# Altium schematic sheet POWER_MCU.SchDoc
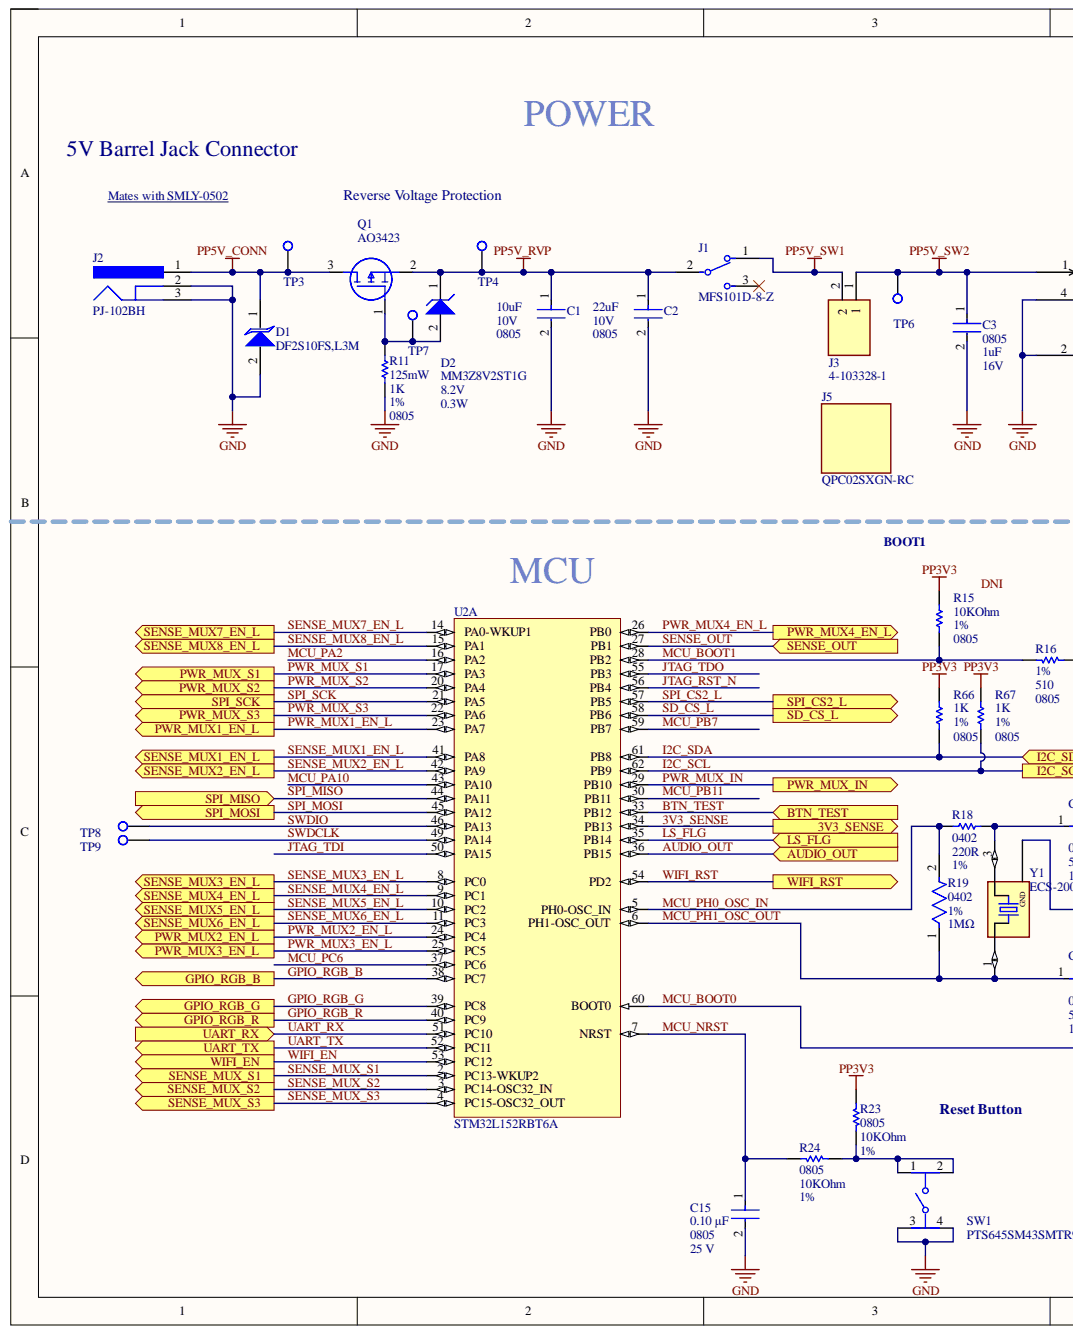
[diagram p0: POWER_MCU.SchDoc, left]
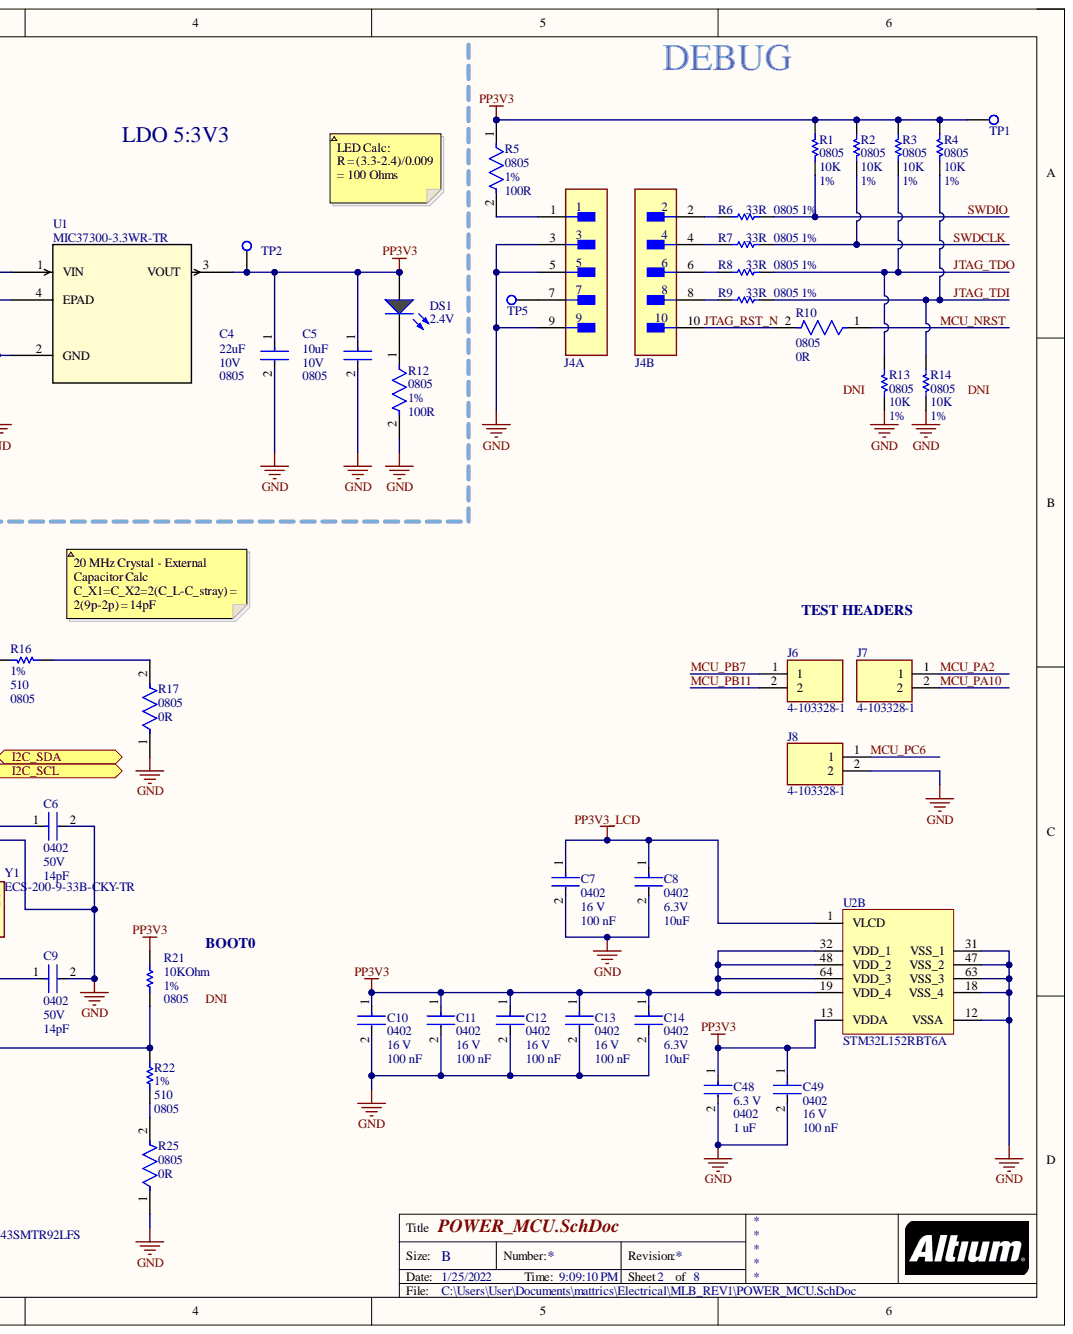
[diagram p1: POWER_MCU.SchDoc, right]

## Parts
C1  Capacitance: 10uF  Case/Package: 0805  Voltage Rating (DC): 10V  [FP-GRM21B-0_15-IPC_A]  (hidden comment: GRM21BR71A106KA73K)
C2  Capacitance: 22uF  Case Code (Imperial): 0805  Voltage Rating: 10V  [FP-GRM21B-0_15-IPC_A]  (hidden comment: GRM21BR61A226ME51L)
C3  Capacitance: 1uF  Case Code (Imperial): 0805  Voltage: 16V  [FP-CL21-IPC_A]  (hidden comment: CL21B105KOFNNNE)
C4  Capacitance: 22uF  Case Code (Imperial): 0805  Voltage Rating: 10V  [FP-GRM21B-0_15-IPC_A]  (hidden comment: GRM21BR61A226ME51L)
C5  Capacitance: 10uF  Case/Package: 0805  Voltage Rating (DC): 10V  [FP-GRM21B-0_15-IPC_A]  (hidden comment: GRM21BR71A106KA73K)
C6  Capacitance: 14pF  Package Reference: 0402  Voltage Rating (DC): 50V  [FP-CC0402-IPC_B]  (hidden comment: GJM1555C1H140GB01D)
C7  value 100 nF  Capacitance: 100 nF  Package: 0402  Voltage Rating: 16 V  [FP-CC0402-IPC_B]  (hidden comment: CC0402KRX7R7BB104)
C8  Capacitance: 10uF  Package: 0402  Voltage: 6.3V  [FP-CC0402-IPC_B]  (hidden comment: GRM155R60J106ME05D)
C9  Capacitance: 14pF  Package Reference: 0402  Voltage Rating (DC): 50V  [FP-CC0402-IPC_B]  (hidden comment: GJM1555C1H140GB01D)
C10  value 100 nF  Package: 0402  Voltage Rating: 16 V  [FP-CC0402-IPC_B]  (hidden comment: CC0402KRX7R7BB104)
C11  value 100 nF  Capacitance: 100 nF  Package: 0402  Voltage Rating: 16 V  [FP-CC0402-IPC_B]  (hidden comment: CC0402KRX7R7BB104)
C12  value 100 nF  Capacitance: 100 nF  Package: 0402  Voltage Rating: 16 V  [FP-CC0402-IPC_B]  (hidden comment: CC0402KRX7R7BB104)
C13  value 100 nF  Capacitance: 100 nF  Package: 0402  Voltage Rating: 16 V  [FP-CC0402-IPC_B]  (hidden comment: CC0402KRX7R7BB104)
C14  Capacitance: 10uF  Package: 0402  Voltage: 6.3V  [FP-CC0402-IPC_B]  (hidden comment: GRM155R60J106ME05D)
C15  value 0.10 μF  Capacitance: 0.10 μF  Package: 0805  Voltage Rating: 25 V  [FP-C0805C-DN-MFG]  (hidden comment: C0805C104M3RACTU)
C48  value 1 uF  Capacitance: 1 uF  Package: 0402  Voltage Rating: 6.3 V  [FP-CC0402-IPC_B]  (hidden comment: CC0402KRX5R5BB105)
C49  value 100 nF  Capacitance: 100 nF  Package: 0402  Voltage Rating: 16 V  [FP-CC0402-IPC_B]  (hidden comment: CC0402KRX7R7BB104)
D1  DF2S10FS,L3M  [DIOM106X45N]
D2  MM3Z8V2ST1G  [ONSC-SOD-323-2-477-02_V]
DS1  Forward Voltage: 2.4V  [DIAL-0805-2_V]  (hidden comment: 598-8170-107F)
J1  MFS101D-8-Z  [CONN03_MFS_11P8X5P8_NDC]
J2  PJ-102BH  [CUI-PJ-102BH_V]
J3  4-103328-1  [TE_4-103328-1]
J4  3220-10-0300-00  [CNC_Tech_0_MFG]  (value not drawn)  (parts A,B)
J5  QPC02SXGN-RC  [FP-QPC02SXGN-RC-MFG]
J6  4-103328-1  [TE_4-103328-1]
J7  4-103328-1  [TE_4-103328-1]
J8  4-103328-1  [TE_4-103328-1]
Q1  AO3423  [SOT95P280X125-3N]
R1  value 10K  PackageReference: 0805  Tolerance: 1%  [RESC2013X60X35NL10T20]  (hidden comment: RC0805FR-0710KL)
R2  value 10K  PackageReference: 0805  Tolerance: 1%  [RESC2013X60X35NL10T20]  (hidden comment: RC0805FR-0710KL)
R3  value 10K  PackageReference: 0805  Tolerance: 1%  [RESC2013X60X35NL10T20]  (hidden comment: RC0805FR-0710KL)
R4  value 10K  PackageReference: 0805  Tolerance: 1%  [RESC2013X60X35NL10T20]  (hidden comment: RC0805FR-0710KL)
R5  Case Code (Imperial): 0805  Resistance: 100R  Tolerance: 1%  [FP-RMCF0805-IPC_A]  (hidden comment: RMCF0805FT100R)
R6  Package Reference: 0805  Resistance: 33R  Tolerance: 1%  [RESC2013X60X35NL10T20]  (hidden comment: RC0805FR-0733RL)
R7  Package Reference: 0805  Resistance: 33R  Tolerance: 1%  [RESC2013X60X35NL10T20]  (hidden comment: RC0805FR-0733RL)
R8  Package Reference: 0805  Resistance: 33R  Tolerance: 1%  [RESC2013X60X35NL10T20]  (hidden comment: RC0805FR-0733RL)
R9  Package Reference: 0805  Resistance: 33R  [RESC2013X60X35NL10T20]  (hidden comment: RC0805FR-0733RL)
R10  Case Code (Imperial): 0805  Resistance: 0R  [FP-RMCF0805-MFG]  (hidden comment: RMCF0805ZT0R00)
R11  Case Code (Imperial): 0805  Max Power Dissipation: 125mW  Resistance: 1K  Tolerance: 1%  [RESC2013X60X35ML10T20]  (hidden comment: RC0805FR-071KL)
R12  Case Code (Imperial): 0805  Resistance: 100R  Tolerance: 1%  [FP-RMCF0805-IPC_A]  (hidden comment: RMCF0805FT100R)
R13  value 10K  PackageReference: 0805  Tolerance: 1%  [RESC2013X60X35NL10T20]  (hidden comment: RC0805FR-0710KL)
R14  value 10K  PackageReference: 0805  Tolerance: 1%  [RESC2013X60X35NL10T20]  (hidden comment: RC0805FR-0710KL)
R15  value 10KOhm  PackageReference: 0805  Tolerance: 1%  [RESC2013X60X35NL10T20]  (hidden comment: RC0805FR-0710KL)
R16  Package Reference: 0805  Tolerance: 1%  [RESC2013X70X40NL20T20]  (hidden comment: ERJ-6ENF5100V)
R17  Case Code (Imperial): 0805  Resistance: 0R  [FP-RMCF0805-MFG]  (hidden comment: RMCF0805ZT0R00)
R18  Package Reference: 0402  [FP-CC0402-IPC_B]  (hidden comment: RC0402FR-07220RL)
R19  value 1MΩ  Package Reference: 0402  Resistance: 1MΩ  Tolerance: 1%  [FP-CC0402-IPC_B]  (hidden comment: CRGCQ0402F1M0)
R21  value 10KOhm  PackageReference: 0805  Tolerance: 1%  [RESC2013X60X35NL10T20]  (hidden comment: RC0805FR-0710KL)
R22  Package Reference: 0805  Tolerance: 1%  [RESC2013X70X40NL20T20]  (hidden comment: ERJ-6ENF5100V)
R23  value 10KOhm  PackageReference: 0805  Tolerance: 1%  [RESC2013X60X35NL10T20]  (hidden comment: RC0805FR-0710KL)
R24  value 10KOhm  Package Reference: 0805  Tolerance: 1%  [RESC2013X50X30NL20T20]  (hidden comment: CRCW080515K0FKTA)
R25  Case Code (Imperial): 0805  Resistance: 0R  [FP-RMCF0805-MFG]  (hidden comment: RMCF0805ZT0R00)
R66  Resistance: 1K  Tolerance: 1%  [RESC2013X60X35ML10T20]  (hidden comment: RC0805FR-071KL)
R67  Case Code (Imperial): 0805  Resistance: 1K  Tolerance: 1%  [RESC2013X60X35ML10T20]  (hidden comment: RC0805FR-071KL)
SW1  PTS645SM43SMTR92LFS  [FP-PTS645SM43SMTR92LFS-MFG]
TP1  RCU-0C  [TP+195X110]  (value not drawn)
TP2  RCU-0C  [TP+195X110]  (value not drawn)
TP3  RCU-0C  [TP+195X110]  (value not drawn)
TP4  RCU-0C  [TP+195X110]  (value not drawn)
TP5  RCU-0C  [TP+195X110]  (value not drawn)
TP6  RCU-0C  [TP+195X110]  (value not drawn)
TP7  RCU-0C  [TP+195X110]  (value not drawn)
TP8  RCU-0C  [TP+195X110]  (value not drawn)
TP9  RCU-0C  [TP+195X110]  (value not drawn)
U1  MIC37300-3.3WR-TR  [S-PAK-3_R_MCH]
U2  STM32L152RBT6A  [STM-LQFP64_L]  (parts A,B)
Y1  ECS-200-9-33B-CKY-TR  [XTAL_ECS-260-9-33B-CKY-TR]

## Ports
3V3_SENSE, AUDIO_OUT, BTN_TEST, GPIO_RGB_B, GPIO_RGB_G, GPIO_RGB_R, I2C_SCL, I2C_SDA, LS_FLG, PWR_MUX1_EN_L, PWR_MUX2_EN_L, PWR_MUX3_EN_L, PWR_MUX4_EN_L, PWR_MUX_IN, PWR_MUX_S1, PWR_MUX_S2, PWR_MUX_S3, SD_CS_L, SENSE_MUX1_EN_L, SENSE_MUX2_EN_L, SENSE_MUX3_EN_L, SENSE_MUX4_EN_L, SENSE_MUX5_EN_L, SENSE_MUX6_EN_L, SENSE_MUX7_EN_L, SENSE_MUX8_EN_L, SENSE_MUX_S1, SENSE_MUX_S2, SENSE_MUX_S3, SENSE_OUT, SPI_CS2_L, SPI_MISO, SPI_MOSI, SPI_SCK, UART_RX, UART_TX, WIFI_EN, WIFI_RST

## Nets
GND: C1.2 C2.2 C3.2 C4.2 C5.2 C6.2 C7.2 C8.2 C9.2 C10.2 C11.2 C12.2 C13.2 C14.2 C15.2 C48.2 C49.2 D1.2 J2.2 J2.3 J4.3 J4.5 J4.9 J8.2 R11.1 R12.2 R13.1 R14.1 R17.1 R25.1 SW1.3 SW1.4 U1.2(GND) U1.4(EPAD) U2.12(VSSA) U2.18(VSS_4) U2.31(VSS_1) U2.47(VSS_2) U2.63(VSS_3) Y1.4
PP3V3: C4.1 C5.1 C10.1 C11.1 C12.1 C13.1 C14.1 C48.1 C49.1 DS1.1 R1.2 R2.2 R3.2 R4.2 R5.1 R15.2 R21.2 R23.2 R66.1 R67.1 TP1.1 TP2.1 U1.3(VOUT) U2.13(VDDA) U2.19(VDD_4) U2.32(VDD_1) U2.48(VDD_2) U2.64(VDD_3)
PP3V3_LCD: C7.1 C8.1 U2.1(VLCD)
PP5V_CONN: D1.1 J2.1 Q1.3 TP3.1
PP5V_RVP: C1.1 C2.1 D2.1 J1.2 Q1.2 TP4.1
PP5V_SW1: J1.1 J3.2
PP5V_SW2: C3.1 J3.1 TP6.1 U1.1(VIN)
3V3_SENSE: U2.34(PB13) ; port 3V3_SENSE
AUDIO_OUT: U2.36(PB15) ; port AUDIO_OUT
BTN_TEST: U2.33(PB12) ; port BTN_TEST
GPIO_RGB_B: U2.38(PC7) ; port GPIO_RGB_B
GPIO_RGB_G: U2.39(PC8) ; port GPIO_RGB_G
GPIO_RGB_R: U2.40(PC9) ; port GPIO_RGB_R
I2C_SCL: R67.2 U2.62(PB9) ; port I2C_SCL
I2C_SDA: R66.2 U2.61(PB8) ; port I2C_SDA
JTAG_RST_N: J4.10 R10.2 U2.56(PB4)
JTAG_TDI: R4.1 R9.2 R14.2 U2.50(PA15)
JTAG_TDO: R3.1 R8.2 R13.2 U2.55(PB3)
LS_FLG: U2.35(PB14) ; port LS_FLG
MCU_BOOT0: R21.1 R22.2 U2.60(BOOT0)
MCU_BOOT1: R15.1 R16.2 U2.28(PB2)
MCU_NRST: C15.1 R10.1 R24.2 U2.7(NRST)
MCU_PA2: J7.1 U2.16(PA2)
MCU_PA10: J7.2 U2.43(PA10)
MCU_PB7: J6.1 U2.59(PB7)
MCU_PB11: J6.2 U2.30(PB11)
MCU_PC6: J8.1 U2.37(PC6)
MCU_PH0_OSC_IN: R18.1 R19.2 U2.5(PH0-OSC_IN)
MCU_PH1_OSC_OUT: C9.1 R19.1 U2.6(PH1-OSC_OUT) Y1.1
PWR_MUX1_EN_L: U2.23(PA7) ; port PWR_MUX1_EN_L
PWR_MUX2_EN_L: U2.24(PC4) ; port PWR_MUX2_EN_L
PWR_MUX3_EN_L: U2.25(PC5) ; port PWR_MUX3_EN_L
PWR_MUX4_EN_L: U2.26(PB0) ; port PWR_MUX4_EN_L
PWR_MUX_IN: U2.29(PB10) ; port PWR_MUX_IN
PWR_MUX_S1: U2.17(PA3) ; port PWR_MUX_S1
PWR_MUX_S2: U2.20(PA4) ; port PWR_MUX_S2
PWR_MUX_S3: U2.22(PA6) ; port PWR_MUX_S3
SD_CS_L: U2.58(PB6) ; port SD_CS_L
SENSE_MUX1_EN_L: U2.41(PA8) ; port SENSE_MUX1_EN_L
SENSE_MUX2_EN_L: U2.42(PA9) ; port SENSE_MUX2_EN_L
SENSE_MUX3_EN_L: U2.8(PC0) ; port SENSE_MUX3_EN_L
SENSE_MUX4_EN_L: U2.9(PC1) ; port SENSE_MUX4_EN_L
SENSE_MUX5_EN_L: U2.10(PC2) ; port SENSE_MUX5_EN_L
SENSE_MUX6_EN_L: U2.11(PC3) ; port SENSE_MUX6_EN_L
SENSE_MUX7_EN_L: U2.14(PA0-WKUP1) ; port SENSE_MUX7_EN_L
SENSE_MUX8_EN_L: U2.15(PA1) ; port SENSE_MUX8_EN_L
SENSE_MUX_S1: U2.2(PC13-WKUP2) ; port SENSE_MUX_S1
SENSE_MUX_S2: U2.3(PC14-OSC32_IN) ; port SENSE_MUX_S2
SENSE_MUX_S3: U2.4(PC15-OSC32_OUT) ; port SENSE_MUX_S3
SENSE_OUT: U2.27(PB1) ; port SENSE_OUT
SPI_CS2_L: U2.57(PB5) ; port SPI_CS2_L
SPI_MISO: U2.44(PA11) ; port SPI_MISO
SPI_MOSI: U2.45(PA12) ; port SPI_MOSI
SPI_SCK: U2.21(PA5) ; port SPI_SCK
SWDCLK: R2.1 R7.2 TP9.1 U2.49(PA14)
SWDIO: R1.1 R6.2 TP8.1 U2.46(PA13)
UART_RX: U2.51(PC10) ; port UART_RX
UART_TX: U2.52(PC11) ; port UART_TX
WIFI_EN: U2.53(PC12) ; port WIFI_EN
WIFI_RST: U2.54(PD2) ; port WIFI_RST
NetC6_1: C6.1 R18.2 Y1.3
NetD2_2: D2.2 Q1.1 R11.2 TP7.1
NetDS1_2: DS1.2 R12.1
NetJ4_1: J4.1 R5.2
NetJ4_2: J4.2 R6.1
NetJ4_4: J4.4 R7.1
NetJ4_6: J4.6 R8.1
NetJ4_7: J4.7 TP5.1
NetJ4_8: J4.8 R9.1
NetR16_1: R16.1 R17.2
NetR22_1: R22.1 R25.2
NetR23_1: R23.1 R24.1 SW1.1 SW1.2

Not connected: J1 3

## Library data of the parts
C1 C5 = CMP-06035-038134-1: Chip Multilayer Ceramic Capacitors for General Purpose, 0805, 10uF, X7R, 15%, 10%, 10V; Manufacturer: Murata Electronics; Component Kind: Standard; Dielectric: X7R; Length: 2mm; Library Name: Altium Content Vault; Manufacturer Part Number: GRM21BR71A106KA73K; Supplier: Digi-Key; Supplier Part Number: 490-14381-1-ND; Thickness: 1.25mm; Tolerance: 10%; Width: 1.25mm
C2 C4 = CMP-2000-06340-2: Chip Multilayer Ceramic Capacitors for General Purpose, 0805, 22uF, X5R, 15%, 20%, 10V; Manufacturer: Murata Electronics; Case Code (Metric): 2012; Case/Package: 0805; Component Kind: Standard; Depth: 1.25mm; Dielectric: X5R; Height: 1.25mm; Lead Free: Lead Free; Length: 2mm; Library Name: Altium Content Vault; Manufacturer Part Number: GRM21BR61A226ME51L; Max Operating Temperature: 85°C; Min Operating Temperature: -55°C; Mount: Surface Mount; Package Quantity: 3000; Packaging: Tape and Reel; Radiation Hardening: No; REACH SVHC: No SVHC; Supplier: Digi-Key; Supplier Part Number: 490-10511-1-ND; Termination: SMD/SMT; Thickness: 0.055inch; Tolerance: 20%; Voltage Rating (DC): 10V; Weight: 0.000335oz; Width: 0.049inch
C3 = CMP-13271-003098-1: CL21 Series 0805 1uF 16V ±10% Tolerance X7R Multilayer Ceramic Chip Capacitor; Manufacturer: Samsung Electro-Mechanics; Case Code (Metric): 2012; Case/Package: 0805; Component Kind: Standard; Contact Plating: Tin; Depth: 1.25mm; Dielectric: X7R; Height: 1.25mm; Lead Free: Lead Free; Length: 2mm; Library Name: MLBSchLib.SchLib; Manufacturer Part Number: CL21B105KOFNNNE; Max Operating Temperature: 125°C; Min Operating Temperature: -55°C; Mount: Surface Mount; Package Quantity: 1; Packaging: Tape and Reel; Radiation Hardening: No; Supplier: Digi-Key; Supplier Part Number: 1276-1026-1-ND; Thickness: 0.053inch; Tolerance: 10%; Voltage Rating: 16V; Voltage Rating (DC): 16V; Width: 0.049inch
C6 C9 = CMP-06035-028518-1: Multi-Layer Ceramic Capacitor 14pF C0G  ±2% 0402 Paper T/R; Manufacturer: Murata Electronics; Component Kind: Standard; Dielectric: C0G; Length: 1mm; Library Name: MLBSchLib.SchLib; Manufacturer Part Number: GJM1555C1H140GB01D; Supplier: Digi-Key; Supplier Part Number: 490-14443-1-ND; Thickness: 500um; Tolerance: 2%; Width: 500um
C7 C11-C13 C49 = CMP-03422-000048-1: CAP CER 0.1UF 16V X7R 0402; Manufacturer: YAGEO; Automotive Grade: NA; Material: Ceramic; Temperature Coefficient: 30ppm/°C; Tolerance: 0.1; Automotive: No; Category: Cap; Component Kind: Standard; ComponentLink2Description: Datasheet; ComponentLink2URL: http://www.yageo.com/documents/recent/UPY-GP_NP0_16V-to-50V_16.pdf; Device Class L1: Passive Components; Device Class L2: Capacitors; Device Class L3: Ceramic Capacitors; Digikey Description: CAP CER 0.1UF 16V X7R 0402; Footprint Url: http://www.yageo.com/exep/pages/download/literatures/UPY-C_GEN_24.pdf; Height: 0.55mm; Ipc Land Pattern Name: CAPC10050X50; Lead Free: Yes; Library Name: MLBSchLib.SchLib; Manufacturer Part Number: CC0402KRX7R7BB104; Mouser Description: 0402 0.1 uF 16 V ±10 % Tolerance X7R SMT Multilayer Ceramic Capacitor; Octopart: https://octopart.com/cc0402krx7r7bb104-yageo-42244624; RoHS: TRUE; Supplier: Digi-Key; Supplier Part Number: 311-1338-1-ND; Temperature: -55°C to +125°C; Temperature Characteristic: X7R; Temperature Range High: +125°C; Temperature Range Low: -55°C
C8 C14 = CMP-06035-044652-1: Chip Multilayer Ceramic Capacitors for General Purpose, 0402, 10uF, X5R, 15%, 20%, 6.3V; Manufacturer: Murata Electronics; Component Kind: Standard; Library Name: MLBSchLib.SchLib; Manufacturer Part Number: GRM155R60J106ME05D; Supplier: Digi-Key; Supplier Part Number: 490-GRM155R60J106ME05DCT-ND
C10 = CMP-03422-000048-1: CAP CER 0.1UF 16V X7R 0402; Manufacturer: YAGEO; Automotive Grade: NA; Capacitance: 100 nF; Material: Ceramic; Temperature Coefficient: 30ppm/°C; Tolerance: 0.1; Value: 100 nF; Automotive: No; Category: Cap; Component Kind: Standard; ComponentLink2Description: Datasheet; ComponentLink2URL: http://www.yageo.com/documents/recent/UPY-GP_NP0_16V-to-50V_16.pdf; Device Class L1: Passive Components; Device Class L2: Capacitors; Device Class L3: Ceramic Capacitors; Digikey Description: CAP CER 0.1UF 16V X7R 0402; Footprint Url: http://www.yageo.com/exep/pages/download/literatures/UPY-C_GEN_24.pdf; Height: 0.55mm; Ipc Land Pattern Name: CAPC10050X50; Lead Free: Yes; Library Name: MLBSchLib.SchLib; Manufacturer Part Number: CC0402KRX7R7BB104; Mouser Description: 0402 0.1 uF 16 V ±10 % Tolerance X7R SMT Multilayer Ceramic Capacitor; Octopart: https://octopart.com/cc0402krx7r7bb104-yageo-42244624; RoHS: TRUE; Supplier: Digi-Key; Supplier Part Number: 311-1338-1-ND; Temperature: -55°C to +125°C; Temperature Characteristic: X7R; Temperature Range High: +125°C; Temperature Range Low: -55°C
C15 = CMP-2007-00282-2: CAP CER 0.1UF 25V 20% X7R 0805; Manufacturer: KEMET; Material: Ceramic; Temperature Coefficient: 30ppm/°C; Tolerance: 0.2; Automotive: No; Category: Cap; Component Kind: Standard; ComponentLink2Description: Datasheet; ComponentLink2URL: https://content.kemet.com/datasheets/KEM_C1003_C0G_SMD.pdf; Device Class L1: Passive Components; Device Class L2: Capacitors; Device Class L3: Ceramic Capacitors; Digikey Description: CAP CER 0.1UF 25V 20% X7R 0805; Height: 0.88mm; Ipc Land Pattern Name: CAPC200125X78; Lead Free: Yes; Library Name: Altium Content Vault; Manufacturer Part Number: C0805C104M3RACTU; Mouser Description: Multilayer Ceramic Capacitors MLCC - SMD/SMT 25volts 0.1uF X7R 20%; Octopart: https://octopart.com/c0805c104m3ractu-kemet-9138612; RoHS: TRUE; Supplier: Digi-Key; Supplier Part Number: 399-C0805C104M3RAC7800CT-ND; Temperature: -55°C to +125°C; Temperature Characteristic: X7R; Temperature Range High: +125°C; Temperature Range Low: -55°C
C48 = CMP-2100-03591-2: CAP CER 1UF 6.3V X5R 0402; Manufacturer: YAGEO; Automotive Grade: NA; Material: Ceramic; Temperature Coefficient: 30ppm/°C; Tolerance: 0.1; Automotive: No; Category: Cap; Component Kind: Standard; ComponentLink2Description: Datasheet; ComponentLink2URL: http://www.yageo.com/documents/recent/UPY-GP_NP0_16V-to-50V_16.pdf; Device Class L1: Passive Components; Device Class L2: Capacitors; Device Class L3: Ceramic Capacitors; Digikey Description: CAP CER 1UF 6.3V X5R 0402; Footprint Url: http://www.yageo.com/exep/pages/download/literatures/UPY-C_GEN_24.pdf; Height: 0.55mm; Ipc Land Pattern Name: CAPC10050X50; Lead Free: Yes; Library Name: MLBSchLib.SchLib; Manufacturer Part Number: CC0402KRX5R5BB105; Mouser Description: Multilayer Ceramic Capacitors MLCC - SMD/SMT 1uF 6.3V X5R 10%; Octopart: https://octopart.com/cc0402krx5r5bb105-yageo-42244618; RoHS: TRUE; Supplier: Digi-Key; Supplier Part Number: 311-1438-1-ND; Temperature: -55°C to +125°C; Temperature Characteristic: X5R; Temperature Range High: +125°C; Temperature Range Low: -55°C
D1 = DF2S10FS,L3M: TVS DIODE 8VWM FSC; Manufacturer: Toshiba Semiconductor and Storage; Manufacturer_Name: Toshiba; Arrow Part Number: DF2S10FS,L3M; Arrow Price/Stock: https://www.arrow.com/en/products/df2s10fsl3m/toshiba?region=nac; Component Kind: Standard; Datasheet Link: https://componentsearchengine.com/Datasheets/1/DF2S10FS,L3M.pdf; Height: 0.45mm; Library Name: MLBSchLib.SchLib; Manufacturer Part Number: DF2S10FS,L3M; Manufacturer_Part_Number: DF2S10FS,L3M; Mouser Part Number: 757-DF2S10FSL3M; Mouser Price/Stock: https://www.mouser.co.uk/ProductDetail/Toshiba/DF2S10FSL3M?qs=lhkzy8lC%252BSsM5fAm2E7mCQ%3D%3D; Supplier: Digi-Key; Supplier Part Number: DF2S10FSL3MCT-ND
D2 = MM3Z8V2ST1G: Zener Voltage Regulator, 300 mW, Tight Tolerance Portfolio, 2-Pin SOD-323, Pb-Free, Tape and Reel; Manufacturer: On Semiconductor; P Max (W): 0.3W; Zener Voltage: 8.2V; Component Kind: Standard; ComponentLink1Description: Manufacturer URL; ComponentLink1URL: http://www.onsemi.com/; ComponentLink2Description: Datasheet; ComponentLink2URL: http://www.onsemi.cn/pub_link/Collateral/MM3Z2V4ST1-D.PDF; ComponentLink3Description: Package Specification; ComponentLink3URL: http://www.onsemi.com/pub_link/Collateral/477-02.PDF.1; DatasheetVersion: Rev. 21; Library Name: MLBSchLib.SchLib; Manufacturer Part Number: MM3Z8V2ST1G; Mounting Technology: Surface Mount; PackageDescription: 2-Pin SOD, Body 1.7 x 1.25 mm; PackageReference: SOD-323-2-477-02; PackageVersion: Rev. H, 03/2007; Packing: Tape and Reel; RoHS: Pb-Free; Supplier: Digi-Key; Supplier Part Number: MM3Z8V2ST1GOSCT-ND
DS1 = CMP-2000-05655-1: Single Color, 2 V, -30 to 85 degC, 2-Pin SMD (0805), RoHS, Tape and Reel; Manufacturer: Dialight; Manufacturer Part Number: 5988170107F; Chip Material: GaP; DELETED: Compliant; Dominant Wave Length: 570nm; Emitting Color: YellowGreen; Forward Current: 25mA; High Brightness: No; Lum Intensity: 20mcd; Main Category: ChipLED; Number of  Elements: 1; Power Dissipation: 60W; Product Depth (mm): 1.25mm; Product Height (mm): 0.7mm; Product Length (mm): 2mm; Reverse Voltage: 5V; Shape Type: Rectangular; Test Current (It): 20mA; Type: Uni-Color; Viewing Angle: 140deg; Component Kind: Standard; Datasheet URL: https://datasheet.ciiva.com/22748/1484480-22748121.pdf; Datasheet Version: 10/2008; Lens Dimensions: 1.4x1.25x0.4mm; Library Name: Altium Content Vault; Luminous Intensity: 20mcd; Manufacturer URL: http://www.dialight.com/; Mounting: SurfaceMount; Mounting Technology: SurfaceMount; Operating Temp Range: -30Cto85C; Operating Temperature Classification: Commercial; Package Description: 2-Pin Surface Mount Device, Body 2 x 1.25 mm; Package Reference: 0805; Package Type: SMD; Packaging: TapeandReel; Pin Count: 2; Rad Hardened: No; Supplier: Digi-Key; Supplier Part Number: 350-2044-1-ND
J1 = MFS101D-8-Z: No Description Available; Manufacturer: Nidec Copal Electronics; Component Kind: Standard; Library Name: MFS101D-8-Z.SchLib; Manufacturer Part Number: MFS101D-8-Z; RefDes: RefDes; Supplier: Digi-Key; Supplier Part Number: 563-1556-ND; Type: DEV; Copyright: Copyright (C) 2022 Ultra Librarian. All rights reserved.
J2 = PJ-102BH: Through Hole Right Angle DC Power Jack, 5 A, 2.5 mm Center Pin, 3 Position, -25 to 85 degC, RoHS, Bulk; Manufacturer: CUI Devices; Brand: CUI; Current Rating: 5A; Inside Contact Diameter: 2.5mm; Insulation Resistance: 100MOhms; Outside Contact Diameter: 6.5mm; Voltage Rating: 24V; Component Kind: Standard; Connector Type: DCPowerJack; Contact Gender: Male; Contact Material: Copper; Contact Plating: Nickel; Datasheet URL: http://www.cui.com/product/resource/pj-102bh.pdf; Datasheet Version: Rev. 1.02, 05/2016; Factory Pack Quantity: 280; Flammability Rating: UL94V-0; Housing Material: PolybutyleneTerephthalate(PBT); Library Name: MLBSchLib.SchLib; Manufacturer Part Number: PJ-102BH; Manufacturer URL: http://www.cui.com/; Mounting Angle: Right; Mounting Style: ThroughHole; Mounting Technology: ThroughHole; Operating Temperature Range: -25Cto+85C; Package Description: 3-Pin Through Hole Device, Body 14.4 x 9 mm, Height 11 mm; Package Reference: PJ-102BH; Product: Jacks; Product Category: DCPowerConnectors; RoHS: True; Supplier: Digi-Key; Supplier Part Number: CP-102BH-ND; Termination Style: ThroughHole
J3 J6-J8 = 4-103328-1: Connector Header Through Hole 2 position; Manufacturer: TE Connectivity AMP Connectors; MF: TE Connectivity; Availability: Unavailable; Component Kind: Standard; EU_RoHS_Compliance: Compliant; Library Name: MLBSchLib.SchLib; Manufacturer Part Number: 4-103328-1; MP: 4-103328-1; Package: None; Price: None; SnapEDA_Link: https://www.snapeda.com/parts/4-103328-1/TE%20Connectivity%20AMP%20Connectors/view-part/2384420/?ref=snap; Supplier: Digi-Key; Supplier Part Number: A121364-ND; TE_purchase_URL: https://www.te.com/usa-en/product-4-103328-1.html?te_bu=Cor&te_type=disp&te_campaign=seda_glo_cor-seda-global-disp-prtnr-fy19-seda-model-bom-cta_sma-317_1&elqCampaignId=32493
J4 = 3220-10-0300-00: CONN HEADER SMD 10POS 1.27MM; Manufacturer: CNC Tech; Component Kind: Standard; Library Name: MLBSchLib.SchLib; Manufacturer Part Number: 3220-10-0300-00; Supplier: Digi-Key; Supplier Part Number: 1175-1629-ND
J5 = CMP-25666-000001-1: CONN JUMPER SHORTING .100" GOLD; Manufacturer: Sullins; Conn Orientation: Straight; Current Rating: 3A; Number Of Contacts: 2; Pitch: 2.54mm; Automotive: No; Category: Conn; Component Kind: Standard; ComponentLink2Description: Datasheet; ComponentLink2URL: https://s3.amazonaws.com/catalogspreads-pdf/PAGE128-129%20.100%20JUMPER.pdf; Contact Material: Gold; Device Class L1: Connectors; Device Class L2: unset; Device Class L3: unset; Digikey Description: CONN JUMPER SHORTING .100" GOLD; Footprint Url: https://drawings-pdf.s3.amazonaws.com/11510.pdf; Height: 6.17mm; Lead Free: Yes; Library Name: Altium Content Vault; Manufacturer Part Number: QPC02SXGN-RC; Octopart: https://octopart.com/qpc02sxgn-rc-sullins-19878795; Package: 0; RoHS: TRUE; Supplier: Digi-Key; Supplier Part Number: S9337-ND; Temperature: -40°C to +85°C; Temperature Range High: +85°C; Temperature Range Low: -40°C
Q1 = AO3423: MOSFET (P-Channel); Manufacturer: Alpha & Omega Semiconductors; Arrow Part Number: AO3423; Arrow Price/Stock: https://www.arrow.com/en/products/ao3423/alpha-and-omega-semiconductor?region=nac; Component Kind: Standard; Datasheet Link: http://www.aosmd.com/pdfs/datasheet/AO3423.pdf; Height: 1.25mm; Library Name: MLBSchLib.SchLib; Manufacturer Part Number: AO3423; Supplier: Digi-Key; Supplier Part Number: 785-1016-1-ND
R1-R4 R13-R15 R21 R23 = CMP-1659-00013-4: Chip Resistor, 10 KOhm, +/- 1%, 0.125 W, -55 to 155 degC, 0805 (2012 Metric), RoHS, Tape and Reel; Manufacturer: Yageo; Voltage Rating: 150 V; Component Kind: Standard; ComponentLink1Description: Manufacturer URL; ComponentLink1URL: http://www.yageo.com/; ComponentLink2Description: Datasheet; ComponentLink2URL: http://www.yageo.com/documents/recent/PYu-RC_Group_51_RoHS_L_04.pdf; DatasheetVersion: Rev. 4, 02/2016; Height: 0.5 mm; Length: 2 mm; Library Name: Altium Content Vault; Manufacturer Part Number: RC0805FR-0710KL; Max Operating Temperature: 155 degC; Min Operating Temperature: -55 degC; Mount: Surface Mount; Mounting Technology: Surface Mount; Package Quantity: 5000; PackageDescription: 2-Pin Surface Mounted Device, Body 2 x 1.25 mm; Packaging: Tape and Reel; Pins: 2; REACH SVHC: No SVHC; RoHSCompliant: true; Supplier: Digi-Key; Supplier Part Number: RC0805FR-0710KL
R5 R12 = CMP-26527-001731-1: 100Ω ±1% 0.125W 0805 Thick Film Chip Resistor AEC-Q200 compliant; Manufacturer: Stackpole Electronics Inc; Max Power Dissipation: 125mW; Power Rating: 250mW; Temperature Coefficient: 100ppm/°C; Case Code (Metric): 2012; Case/Package: 0805; Component Kind: Standard; Construction: Rectangular; Contact Plating: Tin; Depth: 1.25mm; Features: Automotive AEC-Q200; Height: 0.026inch; Lead Free: Lead Free; Length: 2mm; Library Name: Altium Content Vault; Manufacturer Part Number: RMCF0805FT100R; Max Operating Temperature: 155°C; Military Standard: Not; Min Operating Temperature: -55°C; Mount: Surface Mount; Number of Terminations: 2; Packaging: Tape and Reel; Radiation Hardening: No; Resistor Type: Thick Film; RoHS Compliant: Yes; Series: RMCF; Size Code: 0805; Supplier: Digi-Key; Supplier Part Number: RMCF0805FT100RCT-ND; Width: 0.049inch
R6-R9 = CMP-1659-00015-4: Chip Resistor, 33 Ohm, +/- 1%, 0.125 W, -55 to 155 degC, 0805 (2012 Metric), RoHS, Tape and Reel; Manufacturer: Yageo; Voltage Rating: 150 V; Component Kind: Standard; ComponentLink1Description: Manufacturer URL; ComponentLink1URL: http://www.yageo.com/; ComponentLink2Description: Datasheet; ComponentLink2URL: http://www.yageo.com/documents/recent/PYu-RC_Group_51_RoHS_L_04.pdf; DatasheetVersion: Rev. 4, 02/2016; Height: 0.5 mm; Length: 2 mm; Library Name: MLBSchLib.SchLib; Manufacturer Part Number: RC0805FR-0733RL; Max Operating Temperature: 155 degC; Min Operating Temperature: -55 degC; Mount: Surface Mount; Mounting Technology: Surface Mount; Package Quantity: 5000; PackageDescription: 2-Pin Surface Mounted Device, Body 2 x 1.25 mm; PackageReference: 0805; Packaging: Tape and Reel; Pins: 2; REACH SVHC: No SVHC; RoHSCompliant: true; Supplier: Digi-Key; Supplier Part Number: 311-33.0CRCT-ND
  R9: Tolerance: 1%
R10 R17 R25 = CMP-26527-000017-1: RES 0 OHM JUMPER 1/8W 0805; Manufacturer: Stackpole Electronics Inc; Max Power Dissipation: 125mW; Case Code (Metric): 2012; Case/Package: 0805; Component Kind: Standard; Composition: Thick Film; Contact Plating: Tin; Depth: 1.25mm; Features: Automotive AEC-Q200; Height: 0.026inch; Lead Free: Lead Free; Length: 2mm; Library Name: Altium Content Vault; Manufacturer Part Number: RMCF0805ZT0R00; Max Operating Temperature: 155°C; Military Standard: Not; Min Operating Temperature: -55°C; Mount: Surface Mount; Number of Terminations: 2; Packaging: Tape and Reel; Power Rating: 125mW; Radiation Hardening: No; Supplier: Digi-Key; Supplier Part Number: RMCF0805ZT0R00CT-ND; Width: 0.049inch
R11 = CMP-2100-03671-1: Chip Resistor, 1 KOhm, +/- 1%, 125 mW, -55 to 155 degC, 0805 (2012 Metric), RoHS, Tape and Reel; Manufacturer: Yageo; Temperature Coefficient: 100ppm/degreesC; Voltage Rating: 150V; Case Code (Metric): 2012; Case/Package: 0805; Component Kind: Standard; Composition: ThickFilm; Datasheet URL: http://www.yageo.com/NewPortal/yageodocoutput?fileName=/pdf/R-Chip/PYu-RC_Group_51_RoHS_L_5.pdf; Datasheet Version: Rev. 5, 10/2016; Depth: 1.25mm; Height: 0.024inch; Lead Free: LeadFree; Length: 0.079inch; Library Name: Altium Content Vault; Manufacturer Part Number: RC0805FR-071KL; Manufacturer URL: http://www.yageo.com/; Max Operating Temperature: 155degC; Military Standard: Not; Min Operating Temperature: -55degC; Number of Pins: 2; Number of Terminations: 2; Package Description: 2-Pin Surface Mounted Device, Body 2 x 1.25 mm; Package Quantity: 1; Package Reference: 0805; Packaging: TapeandReel; Power Rating: 125mW; REACH SVHC: Unknown; RoHSCompliant: true; Supplier: Digi-Key; Supplier Part Number: 311-1.00KCRCT-ND; Termination: SMD/SMT; Voltage Rating (DC): 150V; Width: 0.049inch
R16 R22 = CMP-2001-00216-1_1: Manufacturer: Panasonic; Resistance: 510; Component Kind: Standard; Library Name: MLBSchLib.SchLib; Manufacturer Part Number: ERJ-6ENF5100V; Supplier: Digi-Key; Supplier Part Number: P510CCT-ND
R18 = CMP-2002-07511-1: Manufacturer: YAGEO; Resistance: 220R; Tolerance: 1%; Component Kind: Standard; Library Name: MLBSchLib.SchLib; Manufacturer Part Number: RC0402FR-07220RL; Supplier: Digi-Key; Supplier Part Number: 311-220LRCT-ND
R19 = CMP-03211-005885-1: Res Thick Film 0402 1M Ohm 1% 0.063W(1/16W) ±100ppm/C Pad SMD Automotive T/R; Manufacturer: TE Connectivity Passive Product; Power Rating: 0.0625W; Temperature Coefficient: ±100ppm/°C; Voltage Rating: 50V; Ambient Temperature Range High: +70°C; Automotive: Yes; Category: Res; Component Kind: Standard; ComponentLink2Description: Datasheet; ComponentLink2URL: https://www.te.com/commerce/DocumentDelivery/DDEController?Action=showdoc&DocId=Data+Sheet%7F1773204-3%7F1%7Fpdf%7FEnglish%7FENG_DS_1773204-3_1.pdf%7F4-2176347-1378; Composition: Thick Film; Device Class L1: Passive Components; Device Class L2: Resistors; Device Class L3: Chip SMD Resistors; Digikey Description: Res Thick Film 0402 1M Ohm 1% 0.063W(1/16W) ±100ppm/C Pad SMD Automotive T/R; Height: 0.4mm; Ipc Land Pattern Name: RESC10050X35; Lead Free: Yes; Library Name: MLBSchLib.SchLib; Manufacturer Part Number: CRGCQ0402F1M0; Mouser Description: Res Thick Film 0402 1M Ohm 1% 0.063W(1/16W) ±100ppm/C Pad SMD Automotive T/R; Octopart: https://octopart.com/crgcq0402f1m0-te+connectivity-91017950; Package: CRGCQ0402; RoHS: TRUE; Series: CRGCQ; Supplier: Digi-Key; Supplier Part Number: A129663CT-ND; Temperature: -55°C to +155°C; Temperature Range High: +155°C; Temperature Range Low: -55°C
R24 = CMP-2001-04968-1: Manufacturer: Vishay Dale; Component Kind: Standard; Library Name: Altium Content Vault; Manufacturer Part Number: CRCW080515K0FKTA; Supplier: Digi-Key; Supplier Part Number: 541-3019-1-ND
R66 R67 = CMP-2100-03671-1: Chip Resistor, 1 KOhm, +/- 1%, 125 mW, -55 to 155 degC, 0805 (2012 Metric), RoHS, Tape and Reel; Manufacturer: Yageo; Max Power Dissipation: 125mW; Temperature Coefficient: 100ppm/degreesC; Voltage Rating: 150V; Case Code (Metric): 2012; Case/Package: 0805; Component Kind: Standard; Composition: ThickFilm; Datasheet URL: http://www.yageo.com/NewPortal/yageodocoutput?fileName=/pdf/R-Chip/PYu-RC_Group_51_RoHS_L_5.pdf; Datasheet Version: Rev. 5, 10/2016; Depth: 1.25mm; Height: 0.024inch; Lead Free: LeadFree; Length: 0.079inch; Library Name: Altium Content Vault; Manufacturer Part Number: RC0805FR-071KL; Manufacturer URL: http://www.yageo.com/; Max Operating Temperature: 155degC; Military Standard: Not; Min Operating Temperature: -55degC; Number of Pins: 2; Number of Terminations: 2; Package Description: 2-Pin Surface Mounted Device, Body 2 x 1.25 mm; Package Quantity: 1; Package Reference: 0805; Packaging: TapeandReel; Power Rating: 125mW; REACH SVHC: Unknown; RoHSCompliant: true; Supplier: Digi-Key; Supplier Part Number: 311-1.00KCRCT-ND; Termination: SMD/SMT; Voltage Rating (DC): 150V; Width: 0.049inch
  R66: Case Code (Imperial): 0805
SW1 = PTS645SM43SMTR92_LFS: SWITCH TACTILE SPST-NO 0.05A 12V; Manufacturer: C&K; Actuator Length: 0.85mm; Actuator Material: Nylon; Actuator Type: Standard; Contact Resistance: 100mR; Current Rating: 50mA; Illumination Type: Non-Illuminated; Mechanical Life: 100000Cycles; Operating Force: 160gf; Switch Function: Off-Mom; Throw Configuration: SPST; Case/Package: SMD/SMT; Color: Black; Component Kind: Standard; Contact Material: Silver; Contact Plating: Silver; Depth: 9mm; Lead Free: Lead Free; Length: 6mm; Library Name: MLBSchLib.SchLib; Manufacturer Part Number: PTS645SM43SMTR92 LFS; Max Current Rating: 50mA; Max Operating Temperature: 60°C; Max Voltage Rating (DC): 12V; Min Operating Temperature: -20°C; Mount: Surface Mount; Orientation: Straight; Package Quantity: 1; Packaging: Tape and Reel; REACH SVHC: No SVHC; Supplier: Digi-Key; Supplier Part Number: CKN9112CT-ND; Termination: Gull Wing; Voltage Rating (DC): 12V
TP1-TP9 = RCU-0C: Natural PC Test Point , Plating Surface Mount Mounting Type; Manufacturer: TE Connectivity AMP Connectors; Check_prices: https://www.snapeda.com/parts/RCU-0C/TE%20Connectivity/view-part/4791537/?ref=eda; Component Kind: Standard; Library Name: MLBSchLib.SchLib; Manufacturer Part Number: RCU-0C; Package: SON-6 TE Connectivity AMP Connectors; Purchase-URL: https://pricing.snapeda.com/search/part/RCU-0C/?ref=eda; SnapEDA_Link: https://www.snapeda.com/parts/RCU-0C/TE%20Connectivity/view-part/4791537/?ref=snap; Supplier: Digi-Key; Supplier Part Number: A106145CT-ND
U1 = MIC37300-3.3WR-TR: IC REG LINEAR 3.3V 3A SPAK-3; Manufacturer: Microchip Technology; Component Kind: Standard; Library Name: MLBSchLib.SchLib; Manufacturer Part Number: MIC37300-3.3WR-TR; RefDes: RefDes; Supplier: Digi-Key; Supplier Part Number: MIC37300-3.3WR-CT-ND; Type: DEV; Copyright: Copyright (C) 2022 Ultra Librarian. All rights reserved.
U2 = CMP-0236-00055-2: ARM Cortex-M3 32-bit MCU, 128 KB Flash, 16 KB Internal RAM, 51 I/Os, 64-pin LQFP, -40 to 85 degC, Tray; Manufacturer: STMicroelectronics; D/A Converter: 2x12-bit (2 Channel); Data E2PROM nom (B): 4096; FLASH Size (Prog) (kB): 128; I/Os (High Current): 51; Internal RAM Size (kB): 16; Operating Frequency(F) (Processor speed) (MHz): 32; Supply Voltage(Vcc) min (V): 1.65; Component Kind: Standard; ComponentLink1Description: Manufacturer URL; ComponentLink1URL: http://www.st.com/web/en/home.html; ComponentLink2Description: Datasheet; ComponentLink2URL: http://www.st.com/st-web-ui/static/active/en/resource/technical/document/datasheet/CD00277537.pdf; ComponentLink3Description: Package Specification; ComponentLink3URL: http://www.st.com/st-web-ui/static/active/en/resource/technical/document/datasheet/DM00037051.pdf; Core: ARM Cortex-M3; DatasheetVersion: Rev. 8; Library Name: MLBSchLib.SchLib; Manufacturer Part Number: STM32L152RBT6A; Mounting Technology: Surface Mount; PackageDescription: 64-Pin Low Profile Quad Flat Package, 10 x 10 mm Body, 0.5 mm Pitch; PackageReference: LQFP64; PackageVersion: Rev. 4, 06/2013; Packing: Tray; PartNumber: STM32L152RBT6A; Supplier: Mouser; Supplier Part Number: 511-STM32L152RBT6A
Y1 = ECS-200-9-33B-CKY-TR: Crystal 20MHz Â±10ppm (Tol) Â±10ppm (Stability) 9pF FUND 40Ohm 4-Pin Mini-CSMD T/R; Manufacturer: ECS Inc.; Availability: Good; Check_prices: https://www.snapeda.com/parts/ECS-260-9-33B-CKY-TR/ECS%20Inc./view-part/5048361/?ref=eda; Component Kind: Standard; Library Name: MLBSchLib.SchLib; Manufacturer Part Number: ECS-200-9-33B-CKY-TR; MF: ECS Inc.; Package: None; Price: None; Purchase-URL: https://pricing.snapeda.com/search/part/ECS-260-9-33B-CKY-TR/?ref=eda; SnapEDA_Link: https://www.snapeda.com/parts/ECS-260-9-33B-CKY-TR/ECS%20Inc./view-part/5048361/?ref=snap; Supplier: Digi-Key; Supplier Part Number: XC2464CT-ND
